# Altium schematic sheet 08-STM32-01.SchDoc(08-STM32-01)
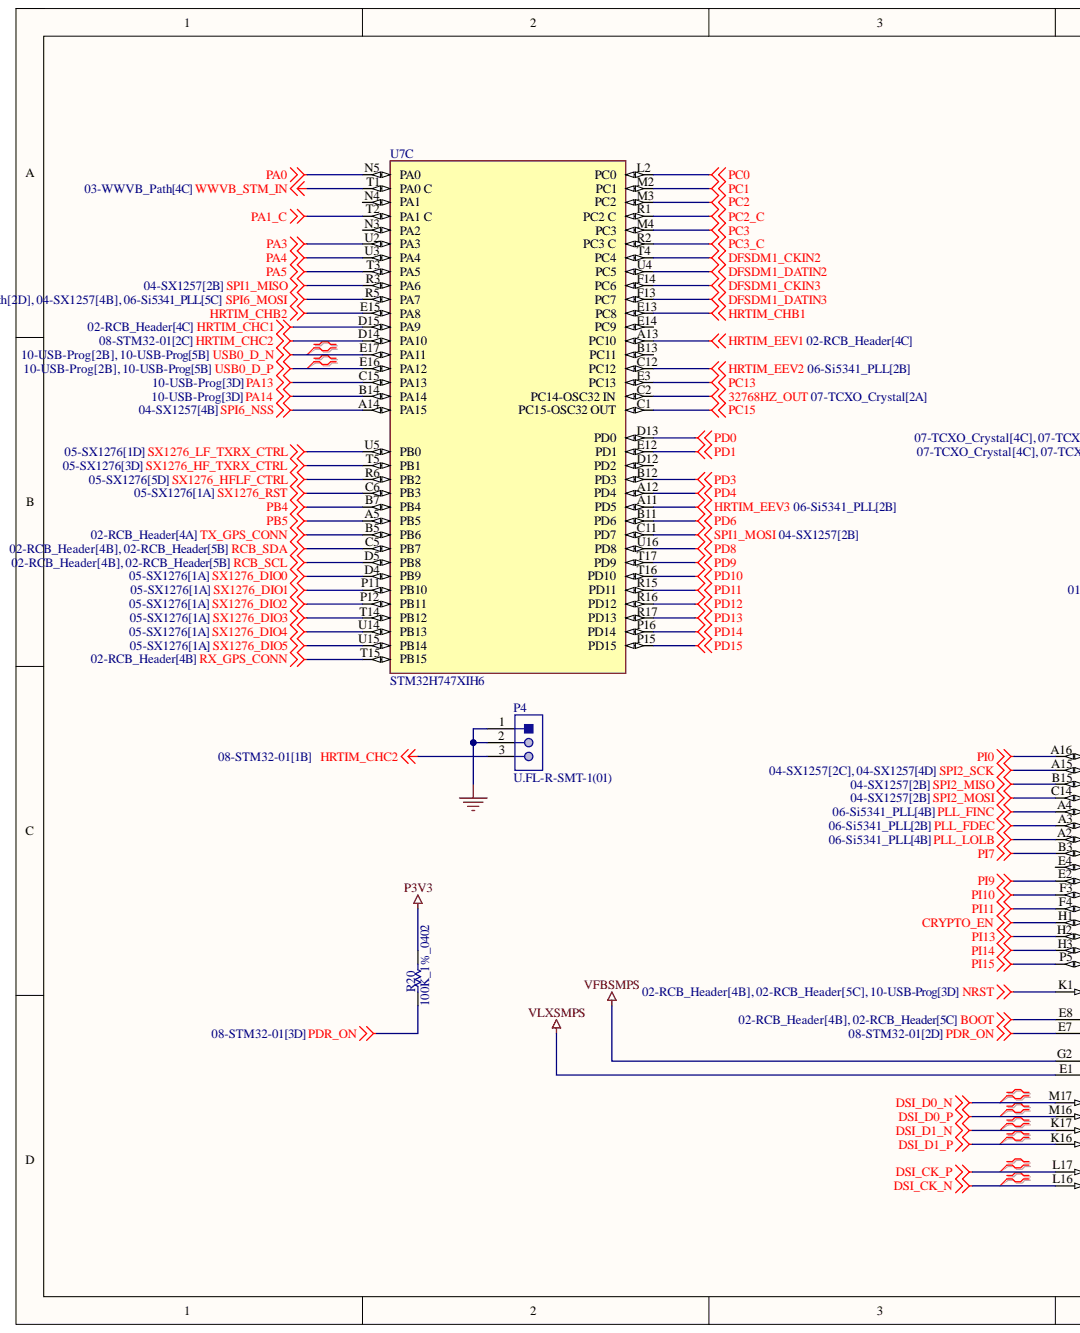
[diagram p0: 08-STM32-01.SchDoc, left]
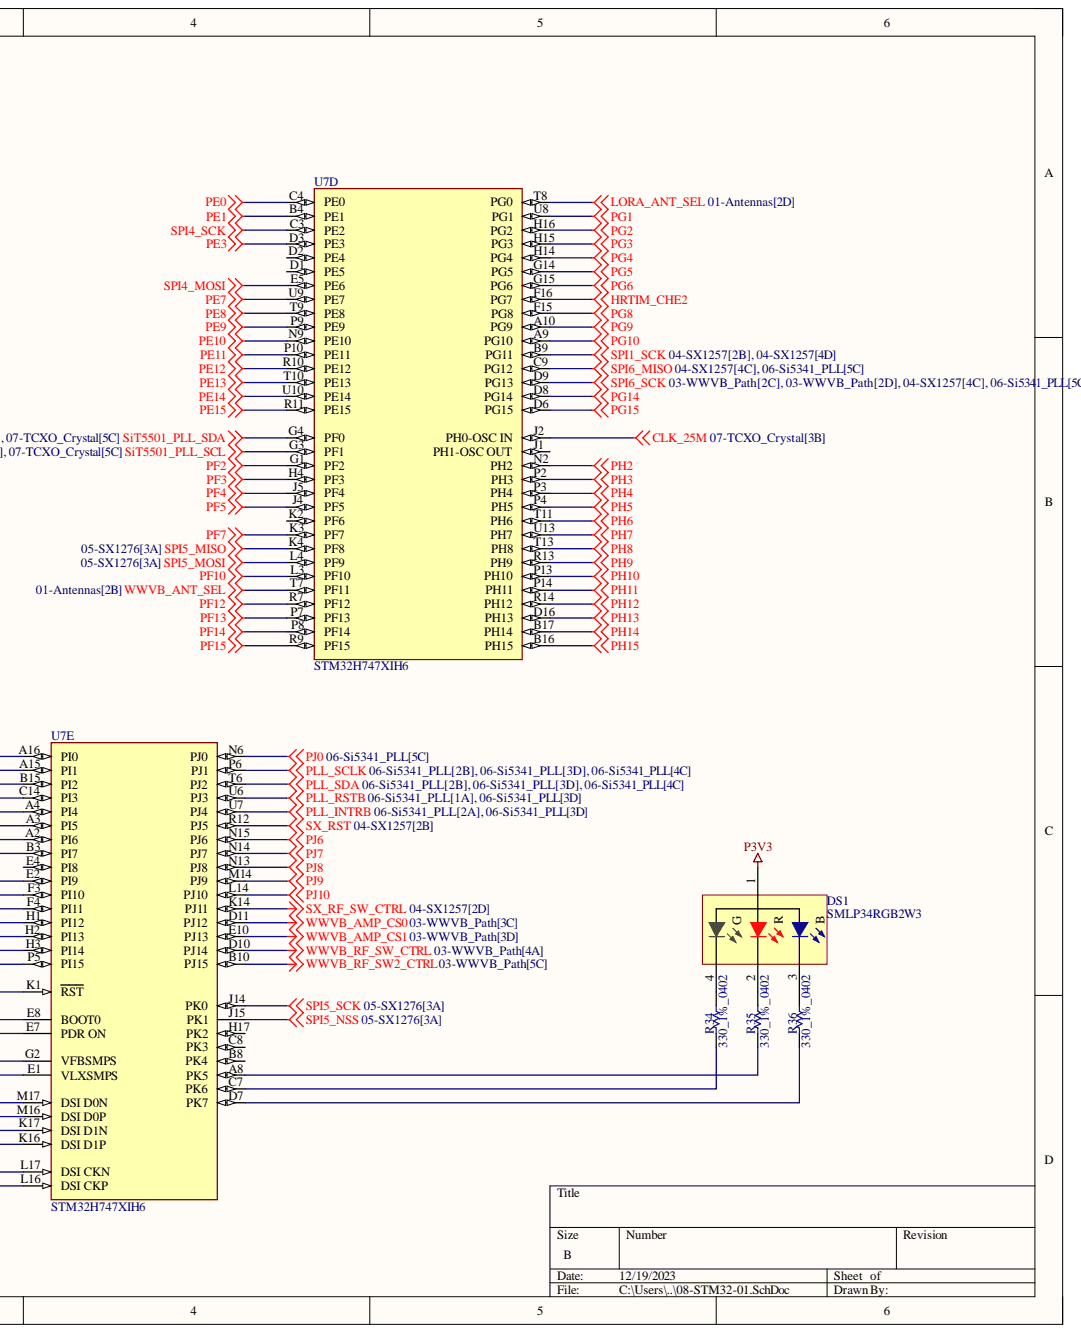
[diagram p1: 08-STM32-01.SchDoc, right]

## Parts
DS1  SMLP34RGB2W3  [ROHM-SMLP34-4_V]
P4  U.FL-R-SMT-1(01)  [HRS-U.FL-R-SMT-3_V]
R20  100K_1%_0402  [RESC1005X40X25ML05T10]
R34  330_1%_0402  [RESC1005X40X25NL10T10]
R35  330_1%_0402  [RESC1005X40X25NL10T10]
R36  330_1%_0402  [RESC1005X40X25NL10T10]
U7  STM32H747XIH6  [FP-TFBGA240+25-A07U-MFG]  (parts C,D,E)

## Nets
32768HZ_OUT: U7.C2(PC14-OSC32_IN)
BOOT: U7.E8(BOOT0)
CLK_25M: U7.J2(PH0-OSC_IN)
CRYPTO_EN: U7.H1(PI12)
DFSDM1_CKIN2: U7.T4(PC4)
DFSDM1_CKIN3: U7.F14(PC6)
DFSDM1_DATIN2: U7.U4(PC5)
DFSDM1_DATIN3: U7.F13(PC7)
DSI_CK_N: U7.L16(DSI_CKP)
DSI_CK_P: U7.L17(DSI_CKN)
DSI_D0_N: U7.M17(DSI_D0N)
DSI_D0_P: U7.M16(DSI_D0P)
DSI_D1_N: U7.K17(DSI_D1N)
DSI_D1_P: U7.K16(DSI_D1P)
GND: P4.1 P4.2
HRTIM_CHB1: U7.E13(PC8)
HRTIM_CHB2: U7.E15(PA8)
HRTIM_CHC1: U7.D15(PA9)
HRTIM_CHC2: P4.3 U7.D14(PA10)
HRTIM_CHE2: U7.F16(PG7)
HRTIM_EEV1: U7.A13(PC10)
HRTIM_EEV2: U7.C12(PC12)
HRTIM_EEV3: U7.A11(PD5)
LORA_ANT_SEL: U7.T8(PG0)
NRST: U7.K1(RST)
P3V3: DS1.1 R20.2
PA0: U7.N5(PA0)
PA1_C: U7.T2(PA1_C)
PA3: U7.U2(PA3)
PA4: U7.U3(PA4)
PA5: U7.T3(PA5)
PA13: U7.C15(PA13)
PA14: U7.B14(PA14)
PB4: U7.B7(PB4)
PB5: U7.A5(PB5)
PC0: U7.L2(PC0)
PC1: U7.M2(PC1)
PC2: U7.M3(PC2)
PC2_C: U7.R1(PC2_C)
PC3: U7.M4(PC3)
PC3_C: U7.R2(PC3_C)
PC13: U7.E3(PC13)
PC15: U7.C1(PC15-OSC32_OUT)
PD0: U7.D13(PD0)
PD1: U7.E12(PD1)
PD3: U7.B12(PD3)
PD4: U7.A12(PD4)
PD6: U7.B11(PD6)
PD8: U7.U16(PD8)
PD9: U7.T17(PD9)
PD10: U7.T16(PD10)
PD11: U7.R15(PD11)
PD12: U7.R16(PD12)
PD13: U7.R17(PD13)
PD14: U7.P16(PD14)
PD15: U7.P15(PD15)
PDR_ON: R20.1 U7.E7(PDR_ON)
PE0: U7.C4(PE0)
PE1: U7.B4(PE1)
PE3: U7.D3(PE3)
PE7: U7.U9(PE7)
PE8: U7.T9(PE8)
PE9: U7.P9(PE9)
PE10: U7.N9(PE10)
PE11: U7.P10(PE11)
PE12: U7.R10(PE12)
PE13: U7.T10(PE13)
PE14: U7.U10(PE14)
PE15: U7.R11(PE15)
PF2: U7.G1(PF2)
PF3: U7.H4(PF3)
PF4: U7.J5(PF4)
PF5: U7.J4(PF5)
PF7: U7.K3(PF7)
PF10: U7.L3(PF10)
PF12: U7.R7(PF12)
PF13: U7.P7(PF13)
PF14: U7.P8(PF14)
PF15: U7.R9(PF15)
PG1: U7.U8(PG1)
PG2: U7.H16(PG2)
PG3: U7.H15(PG3)
PG4: U7.H14(PG4)
PG5: U7.G14(PG5)
PG6: U7.G15(PG6)
PG8: U7.F15(PG8)
PG9: U7.A10(PG9)
PG10: U7.A9(PG10)
PG14: U7.D8(PG14)
PG15: U7.D6(PG15)
PH2: U7.N2(PH2)
PH3: U7.P2(PH3)
PH4: U7.P3(PH4)
PH5: U7.P4(PH5)
PH6: U7.T11(PH6)
PH7: U7.U13(PH7)
PH8: U7.T13(PH8)
PH9: U7.R13(PH9)
PH10: U7.P13(PH10)
PH11: U7.P14(PH11)
PH12: U7.R14(PH12)
PH13: U7.D16(PH13)
PH14: U7.B17(PH14)
PH15: U7.B16(PH15)
PI0: U7.A16(PI0)
PI7: U7.B3(PI7)
PI9: U7.E2(PI9)
PI10: U7.F3(PI10)
PI11: U7.F4(PI11)
PI13: U7.H2(PI13)
PI14: U7.H3(PI14)
PI15: U7.P5(PI15)
PJ0: U7.N6(PJ0)
PJ6: U7.N15(PJ6)
PJ7: U7.N14(PJ7)
PJ8: U7.N13(PJ8)
PJ9: U7.M14(PJ9)
PJ10: U7.L14(PJ10)
PLL_FDEC: U7.A3(PI5)
PLL_FINC: U7.A4(PI4)
PLL_INTRB: U7.U7(PJ4)
PLL_LOLB: U7.A2(PI6)
PLL_RSTB: U7.U6(PJ3)
PLL_SCLK: U7.P6(PJ1)
PLL_SDA: U7.T6(PJ2)
RCB_SCL: U7.D5(PB8)
RCB_SDA: U7.C5(PB7)
RX_GPS_CONN: U7.T15(PB15)
SiT5501_PLL_SCL: U7.G3(PF1)
SiT5501_PLL_SDA: U7.G4(PF0)
SPI1_MISO: U7.R3(PA6)
SPI1_MOSI: U7.C11(PD7)
SPI1_SCK: U7.B9(PG11)
SPI2_MISO: U7.B15(PI2)
SPI2_MOSI: U7.C14(PI3)
SPI2_SCK: U7.A15(PI1)
SPI4_MOSI: U7.E5(PE6)
SPI4_SCK: U7.C3(PE2)
SPI5_MISO: U7.K4(PF8)
SPI5_MOSI: U7.L4(PF9)
SPI5_NSS: U7.J15(PK1)
SPI5_SCK: U7.J14(PK0)
SPI6_MISO: U7.C9(PG12)
SPI6_MOSI: U7.R5(PA7)
SPI6_NSS: U7.A14(PA15)
SPI6_SCK: U7.D9(PG13)
SX1276_DIO0: U7.D4(PB9)
SX1276_DIO1: U7.P11(PB10)
SX1276_DIO2: U7.P12(PB11)
SX1276_DIO3: U7.T14(PB12)
SX1276_DIO4: U7.U14(PB13)
SX1276_DIO5: U7.U15(PB14)
SX1276_HF_TXRX_CTRL: U7.T5(PB1)
SX1276_HFLF_CTRL: U7.R6(PB2)
SX1276_LF_TXRX_CTRL: U7.U5(PB0)
SX1276_RST: U7.C6(PB3)
SX_RF_SW_CTRL: U7.K14(PJ11)
SX_RST: U7.R12(PJ5)
TX_GPS_CONN: U7.B5(PB6)
USB0_D_N: U7.E17(PA11)
USB0_D_P: U7.E16(PA12)
VFBSMPS: U7.G2(VFBSMPS)
VLXSMPS: U7.E1(VLXSMPS)
WWVB_AMP_CS0: U7.D11(PJ12)
WWVB_AMP_CS1: U7.E10(PJ13)
WWVB_ANT_SEL: U7.T7(PF11)
WWVB_RF_SW2_CTRL: U7.B10(PJ15)
WWVB_RF_SW_CTRL: U7.D10(PJ14)
WWVB_STM_IN: U7.T1(PA0_C)
NetDS1_2: DS1.2 R35.2
NetDS1_3: DS1.3 R36.2
NetDS1_4: DS1.4 R34.2
NetR34_1: R34.1 U7.C7(PK6)
NetR35_1: R35.1 U7.A8(PK5)
NetR36_1: R36.1 U7.D7(PK7)

Not connected: U7 B8(PK4) B13(PC11) C8(PK3) D1(PE5) D2(PE4) D12(PD2) E4(PI8) E14(PC9) H17(PK2) J1(PH1-OSC_OUT) K2(PF6) N3(PA2) N4(PA1)

## Library data of the parts
DS1 = CMP-0918-00001-1: PICOLED-RGB LED, 5 mA, -40 to 85 degC, 4-Pin 1 x 1 x 0.25 mm SMD, RoHS, Tape and Reel; Manufacturer: ROHM Semiconductor; IF(RGB): 5 mA; IR(RGB): 10 uA Max.; VF(Blue): 3.2 V Typ.; VF(Green): 3.3 V Typ.; VF(Red): 2.2 V Typ.; VR(RGB): 5 V; Component Kind: Standard; ComponentLink1Description: Manufacturer URL; ComponentLink1URL: http://www.rohm.com/; ComponentLink2Description: Datasheet; ComponentLink2URL: http://rohmfs.rohm.com/en/products/databook/datasheet/opto/led/chip_multi/smlp34.pdf; DatasheetVersion: Rev. G; Library Name: Altium Content Vault; Mounting Technology: Surface Mount; PackageDescription: 4-Pin SMD, Body 1 x 1 mm, Pitch 0.85 mm; PackageReference: SMLP34; Packing: Tape and Reel; PartNumber: SMLP34RGB2W3; RoHS: TRUE; Temperature Range: -40 to 85 degC
P4 = CMP-2000-05153-1: Ultra Small Coaxial Connector, 6 GHz, -40 to 90 degC, 3-Pin SMD, RoHS, Bulk; Manufacturer: Hirose Electric; Body Finish: Silver; Body Material: PhosphorBronze; Center Contact Plating: Gold; Connector Style: U.FL; Connector Type: Jack,MalePin; Contact Termination: Solder; Dielectric Material: LiquidCrystalPolymer(LCP); Dynamic Catalog: U.FLSeries; Featured Product: HiroseU.FLSeries; Frequency - Max: 6GHz; Impedance: 50Ohm; Minimum Quantity: UltraMiniatureCoaxial; Other Names: H122041  U.FL-R-SMT-1(01)-ND; Category: Connectors,Interconnects; Center Contact Material: PhosphorBronze; Component Kind: Standard; Datasheet URL: https://datasheet.ciiva.com/26945/getdatasheetpartid-475239-26945414.pdf; Datasheet Version: Feb-09; Family: CoaxialConnectors(RF); Fastening Type: Snap-On; Housing Color: Silver; Library Name: Altium Content Vault; Manufacturer URL: https://www.hirose.com; Mounting Type: SurfaceMount; Online Catalog: U.FLSeries; Operating Temperature: -40degC~90degC; Package Description: 3-Pin Surface Mount Device, Body 2.6 x 2.6 mm; Package Reference: U.FL-R-SMT; Packaging: Bulk; Quantity Available: Bulk; Series: U.FL; Shield Termination: Solder; Standard Package: 100; Unit PriceUSD: U.FL
R20 = CMP-2002-07852-1: Manufacturer: Yageo; Part Number: RC0402FR-13100KL; Component Kind: Standard; Library Name: Altium Content Vault
R34-R36 = CMP-2002-05853-1: Manufacturer: Panasonic; Part Number: ERA-2AED331X; Component Kind: Standard; Library Name: Altium Content Vault
U7 = CMP-12105-000055-1: HIGH-PERFORMANCE AND DSP WITH DP; Manufacturer: STMicroelectronics; Core Architecture: ARM Cortex-M4, ARM Cortex-M7; Data Bus Width: 32bits; Frequency: 480MHz; Interface: I2C,SPI,UART,USART,CAN,USB,LIN,IrDA,Ethernet,I2S,SMBus,Other; Max Junction Temp: +125°C; Max Supply Voltage: 3.6V; Memory Size: 2MBytes; Memory Type: FLASH; Min Supply Voltage: 1.2V; Number Of A/D Converters: 3; Number Of Adc Channels: 36; Number Of Cores: 2; Number Of Ethernet Channels: 1; Number Of I2C Channels: 4; Number Of I/Os: 168; Number Of Spi Channels: 6; Number Of Timers/Counters: 22; Number Of Uart Channels: 9; Ram Size: 1MBytes; Standoff Height: 0.15mm; Ambient Temperature Range High: +85°C; Ambient Temperature Range Low: -40°C; Automotive: No; Category: IC; Component Kind: Standard; ComponentLink2Description: Datasheet; ComponentLink2URL: https://www.st.com/resource/en/datasheet/stm32h747xi.pdf; Device Class L1: Integrated Circuits (ICs); Device Class L2: Embedded Processors and Controllers; Device Class L3: Microcontrollers; Digikey Description: HIGH-PERFORMANCE AND DSP WITH DP; Height: 1.1mm; Ipc Land Pattern Name: BGA289N80P17X17_1400X1400X110; Lead Free: Yes; Library Name: Altium Content Vault; Manufacturer Part Number: STM32H747XIH6; Mouser Description: STM32 Series 2 MB Flash 1 MB RAM 480 MHz 32-Bit Microcontroller - TFBGA-265; Number Of Pwm Channels: 2; Number Of Usb Channels: 2; Octopart: https://octopart.com/stm32h747xih6-stmicroelectronics-100293943; Package: TFBGA240+25-A07U; RoHS: TRUE; Temperature: -40°C to +125°C; Temperature Range High: +125°C; Temperature Range Low: -40°C
